# Altium schematic sheet [1]-SODIMM-COL-SOM-iMX8.SchDoc([1]-SODIMM-COL-SOM-iMX8)
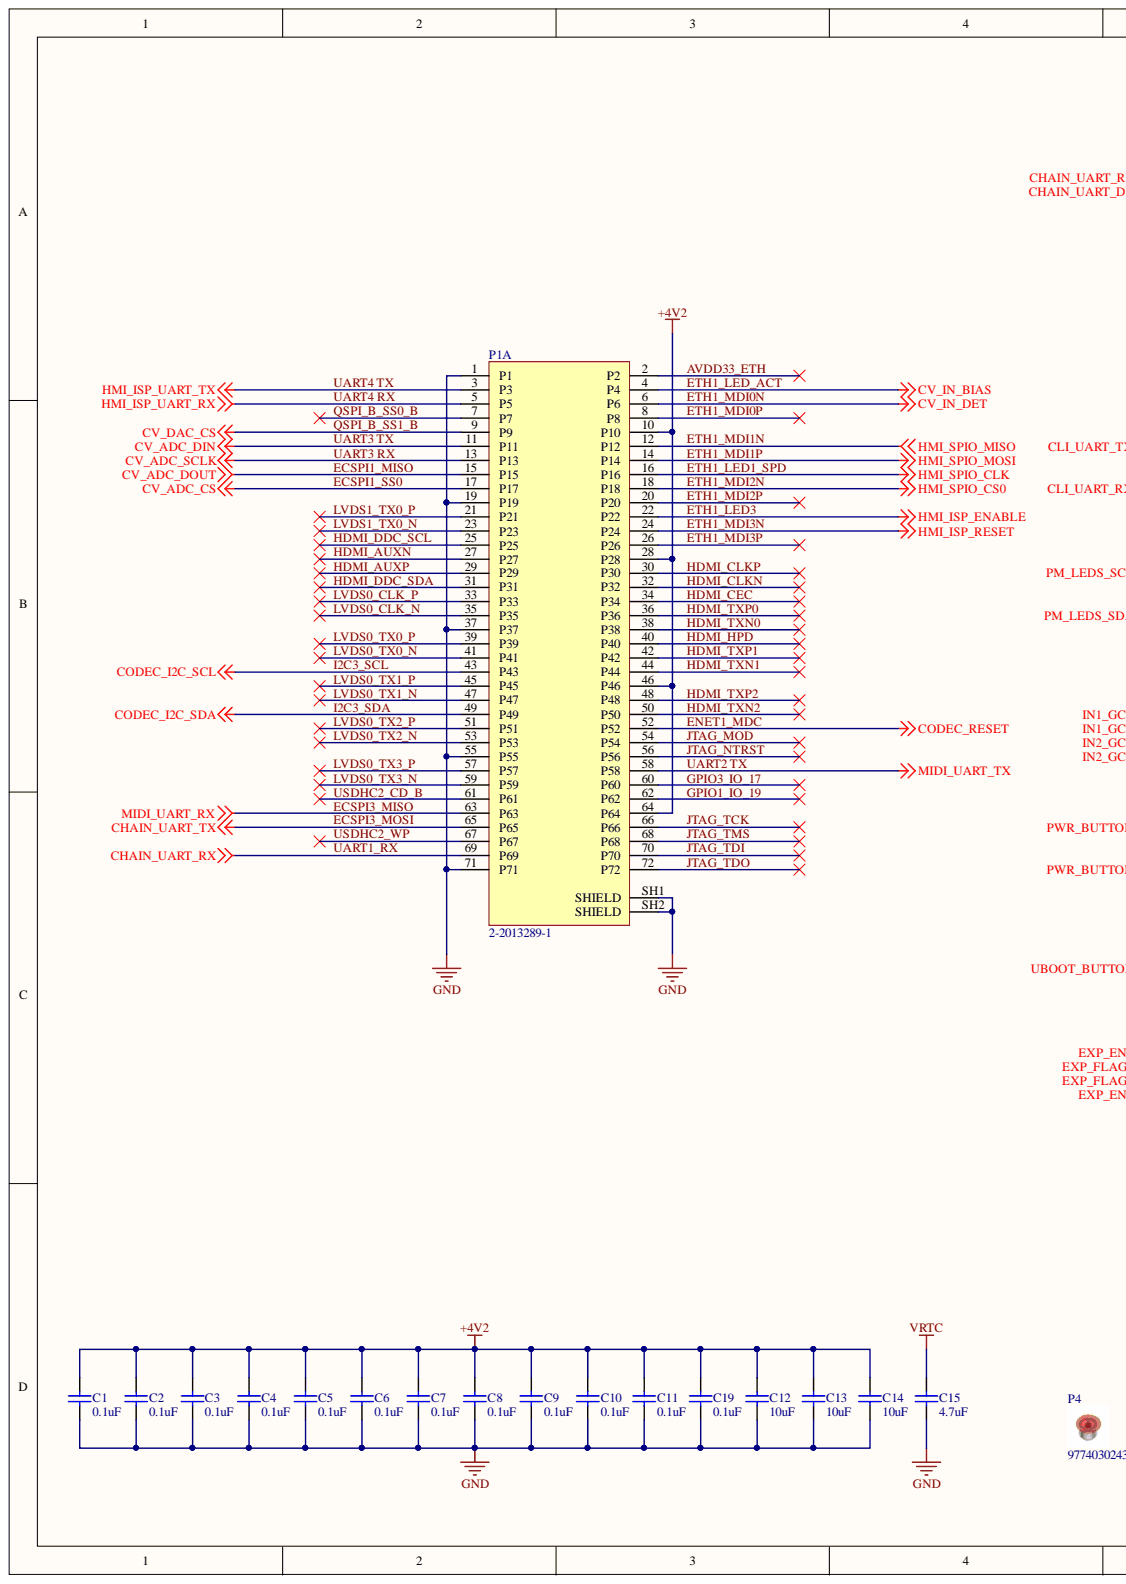
[diagram p0: [1]-SODIMM-COL-SOM-iMX8.SchDoc, left]
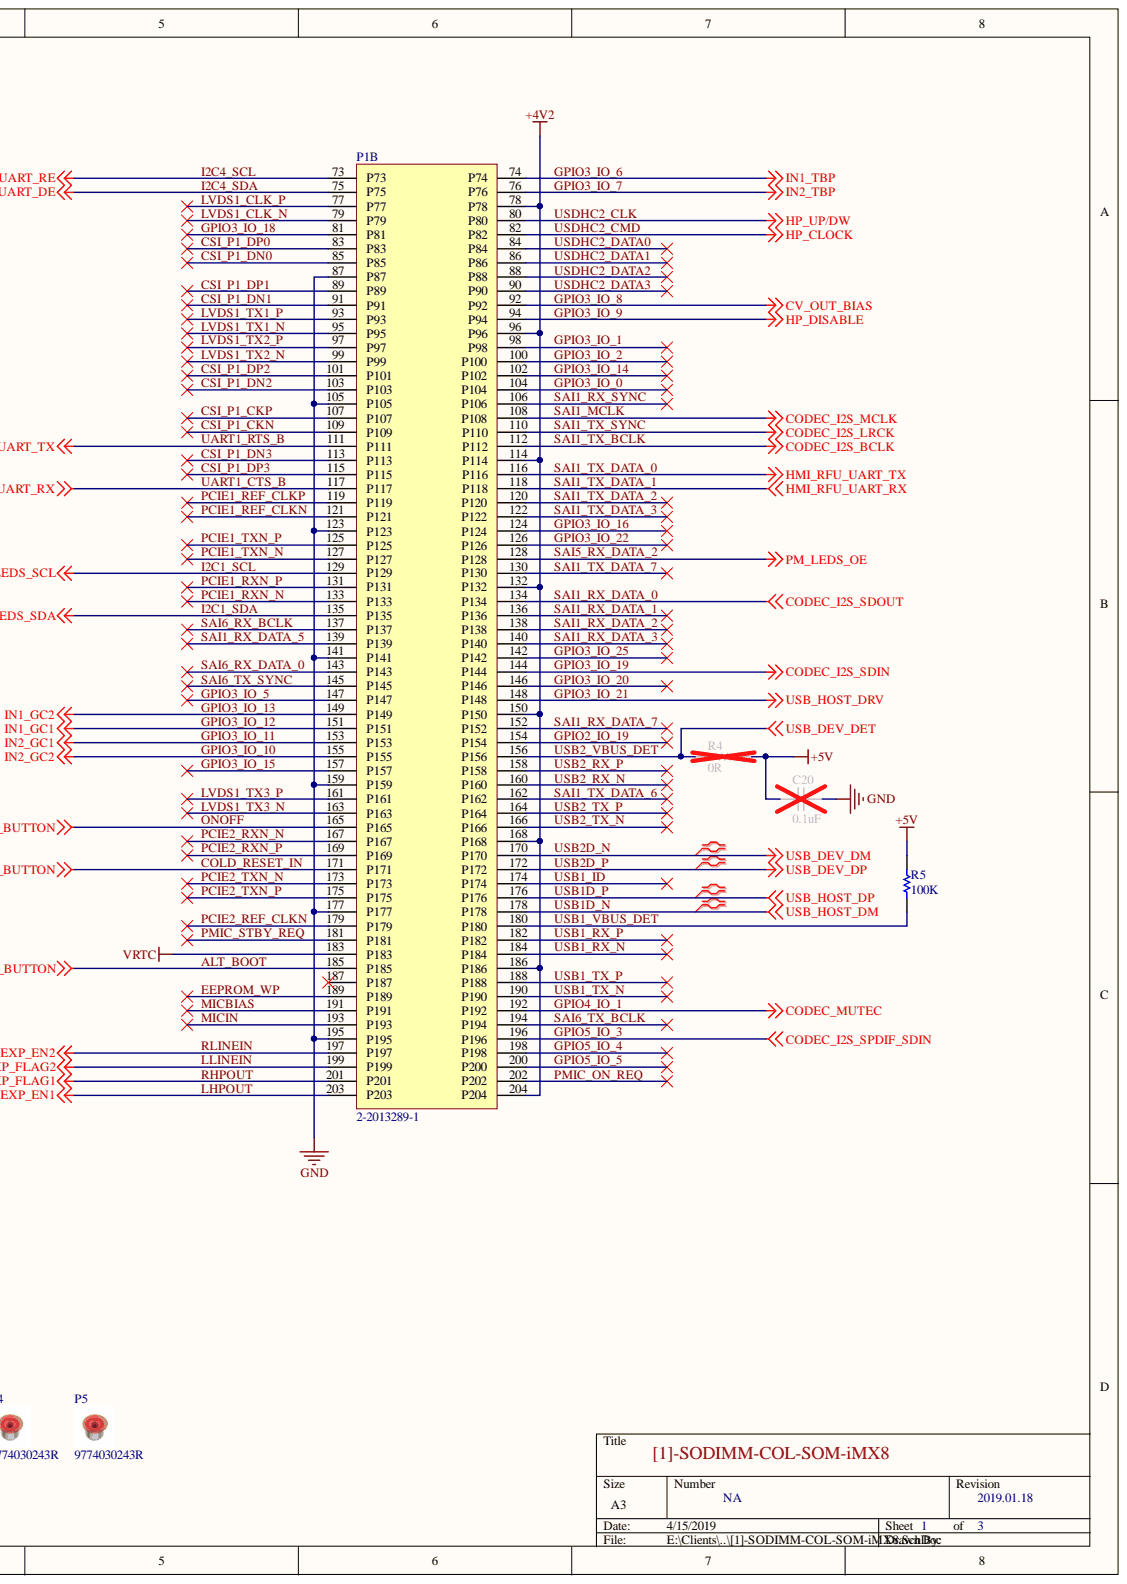
[diagram p1: [1]-SODIMM-COL-SOM-iMX8.SchDoc, right]

## Parts
C1  0.1uF  [CAPC1005N]
C2  0.1uF  [CAPC1005N]
C3  0.1uF  [CAPC1005N]
C4  0.1uF  [CAPC1005N]
C5  0.1uF  [CAPC1005N]
C6  0.1uF  [CAPC1005N]
C7  0.1uF  [CAPC1005N]
C8  0.1uF  [CAPC1005N]
C9  0.1uF  [CAPC1005N]
C10  0.1uF  [CAPC1005N]
C11  0.1uF  [CAPC1005N]
C12  10uF  [CAPC2012N]
C13  10uF  [CAPC2012N]
C14  10uF  [CAPC2012N]
C15  4.7uF  [CAPC1608N]
C19  0.1uF  [CAPC1005N]
C20  0.1uF  [CAPC1005N]
P1  2-2013289-1  [TE_2-2013289-1]  (parts A,B)
P4  9774030243R  [9774030243R]
P5  9774030243R  [9774030243R]
R4  0R  [RESC1608N]
R5  100K  [RESC1005N]

## Nets
+4V2: C1.2 C2.2 C3.2 C4.2 C5.2 C6.2 C7.2 C8.2 C9.2 C10.2 C11.2 C12.2 C13.2 C14.2 C19.2 P1.10(P10) P1.28(P28) P1.46(P46) P1.64(P64) P1.78(P78) P1.96(P96) P1.114(P114) P1.132(P132) P1.150(P150) P1.168(P168) P1.186(P186) P1.204(P204)
+5V: C20.2 R4.2 R5.2
GND: C1.1 C2.1 C3.1 C4.1 C5.1 C6.1 C7.1 C8.1 C9.1 C10.1 C11.1 C12.1 C13.1 C14.1 C15.1 C19.1 C20.1 P1.1 P1.19(P19) P1.37(P37) P1.55(P55) P1.71(P71) P1.87(P87) P1.105(P105) P1.123(P123) P1.141(P141) P1.159(P159) P1.177(P177) P1.195(P195) P1.SH1(SHIELD) P1.SH2(SHIELD)
VRTC: C15.2 P1.183(P183)
ALT_BOOT: P1.185(P185)
AVDD33_ETH: P1.2(P2)
COLD_RESET_IN: P1.165(P165) P1.171(P171)
CSI_P1_CKN: P1.109(P109)
CSI_P1_CKP: P1.107(P107)
CSI_P1_DN0: P1.85(P85)
CSI_P1_DN1: P1.91(P91)
CSI_P1_DN2: P1.103(P103)
CSI_P1_DN3: P1.113(P113)
CSI_P1_DP0: P1.83(P83)
CSI_P1_DP1: P1.89(P89)
CSI_P1_DP2: P1.101(P101)
CSI_P1_DP3: P1.115(P115)
ECSPI1_MISO: P1.15(P15)
ECSPI1_SS0: P1.17(P17)
ECSPI3_MISO: P1.63(P63)
ECSPI3_MOSI: P1.65(P65)
EEPROM_WP: P1.189(P189)
ENET1_MDC: P1.52(P52)
ETH1_LED1_SPD: P1.16(P16)
ETH1_LED3: P1.22(P22)
ETH1_LED_ACT: P1.4(P4)
ETH1_MDI0N: P1.6(P6)
ETH1_MDI0P: P1.8(P8)
ETH1_MDI1N: P1.12(P12)
ETH1_MDI1P: P1.14(P14)
ETH1_MDI2N: P1.18(P18)
ETH1_MDI2P: P1.20(P20)
ETH1_MDI3N: P1.24(P24)
ETH1_MDI3P: P1.26(P26)
GPIO1_IO_19: P1.62(P62)
GPIO2_IO_19: P1.154(P154)
GPIO3_IO_0: P1.104(P104)
GPIO3_IO_1: P1.98(P98)
GPIO3_IO_2: P1.100(P100)
GPIO3_IO_5: P1.147(P147)
GPIO3_IO_6: P1.74(P74)
GPIO3_IO_7: P1.76(P76)
GPIO3_IO_8: P1.92(P92)
GPIO3_IO_9: P1.94(P94)
GPIO3_IO_10: P1.155(P155)
GPIO3_IO_11: P1.153(P153)
GPIO3_IO_12: P1.151(P151)
GPIO3_IO_13: P1.149(P149)
GPIO3_IO_14: P1.102(P102)
GPIO3_IO_15: P1.157(P157)
GPIO3_IO_16: P1.124(P124)
GPIO3_IO_17: P1.60(P60)
GPIO3_IO_18: P1.81(P81)
GPIO3_IO_19: P1.144(P144)
GPIO3_IO_20: P1.146(P146)
GPIO3_IO_21: P1.148(P148)
GPIO3_IO_22: P1.126(P126)
GPIO3_IO_25: P1.142(P142)
GPIO4_IO_1: P1.192(P192)
GPIO5_IO_3: P1.196(P196)
GPIO5_IO_4: P1.198(P198)
GPIO5_IO_5: P1.200(P200)
HDMI_AUXN: P1.27(P27)
HDMI_AUXP: P1.29(P29)
HDMI_CEC: P1.34(P34)
HDMI_CLKN: P1.32(P32)
HDMI_CLKP: P1.30(P30)
HDMI_DDC_SCL: P1.25(P25)
HDMI_DDC_SDA: P1.31(P31)
HDMI_HPD: P1.40(P40)
HDMI_TXN0: P1.38(P38)
HDMI_TXN1: P1.44(P44)
HDMI_TXN2: P1.50(P50)
HDMI_TXP0: P1.36(P36)
HDMI_TXP1: P1.42(P42)
HDMI_TXP2: P1.48(P48)
I2C1_SCL: P1.129(P129)
I2C1_SDA: P1.135(P135)
I2C3_SCL: P1.43(P43)
I2C3_SDA: P1.49(P49)
I2C4_SCL: P1.73(P73)
I2C4_SDA: P1.75(P75)
JTAG_MOD: P1.54(P54)
JTAG_NTRST: P1.56(P56)
JTAG_TCK: P1.66(P66)
JTAG_TDI: P1.70(P70)
JTAG_TDO: P1.72(P72)
JTAG_TMS: P1.68(P68)
LHPOUT: P1.203(P203)
LLINEIN: P1.199(P199)
LVDS0_CLK_N: P1.35(P35)
LVDS0_CLK_P: P1.33(P33)
LVDS0_TX0_N: P1.41(P41)
LVDS0_TX0_P: P1.39(P39)
LVDS0_TX1_N: P1.47(P47)
LVDS0_TX1_P: P1.45(P45)
LVDS0_TX2_N: P1.53(P53)
LVDS0_TX2_P: P1.51(P51)
LVDS0_TX3_N: P1.59(P59)
LVDS0_TX3_P: P1.57(P57)
LVDS1_CLK_N: P1.79(P79)
LVDS1_CLK_P: P1.77(P77)
LVDS1_TX0_N: P1.23(P23)
LVDS1_TX0_P: P1.21(P21)
LVDS1_TX1_N: P1.95(P95)
LVDS1_TX1_P: P1.93(P93)
LVDS1_TX2_N: P1.99(P99)
LVDS1_TX2_P: P1.97(P97)
LVDS1_TX3_N: P1.163(P163)
LVDS1_TX3_P: P1.161(P161)
MICBIAS: P1.191(P191)
MICIN: P1.193(P193)
PCIE1_REF_CLKN: P1.121(P121)
PCIE1_REF_CLKP: P1.119(P119)
PCIE1_RXN_N: P1.133(P133)
PCIE1_RXN_P: P1.131(P131)
PCIE1_TXN_N: P1.127(P127)
PCIE1_TXN_P: P1.125(P125)
PCIE2_REF_CLKN: P1.179(P179)
PCIE2_RXN_N: P1.167(P167)
PCIE2_RXN_P: P1.169(P169)
PCIE2_TXN_N: P1.173(P173)
PCIE2_TXN_P: P1.175(P175)
PMIC_ON_REQ: P1.202(P202)
PMIC_STBY_REQ: P1.181(P181)
QSPI_B_SS0_B: P1.7(P7)
QSPI_B_SS1_B: P1.9(P9)
RHPOUT: P1.201(P201)
RLINEIN: P1.197(P197)
SAI1_MCLK: P1.108(P108)
SAI1_RX_DATA_0: P1.134(P134)
SAI1_RX_DATA_1: P1.136(P136)
SAI1_RX_DATA_2: P1.138(P138)
SAI1_RX_DATA_3: P1.140(P140)
SAI1_RX_DATA_5: P1.139(P139)
SAI1_RX_DATA_7: P1.152(P152)
SAI1_RX_SYNC: P1.106(P106)
SAI1_TX_BCLK: P1.112(P112)
SAI1_TX_DATA_0: P1.116(P116)
SAI1_TX_DATA_1: P1.118(P118)
SAI1_TX_DATA_2: P1.120(P120)
SAI1_TX_DATA_3: P1.122(P122)
SAI1_TX_DATA_6: P1.162(P162)
SAI1_TX_DATA_7: P1.130(P130)
SAI1_TX_SYNC: P1.110(P110)
SAI5_RX_DATA_2: P1.128(P128)
SAI6_RX_BCLK: P1.137(P137)
SAI6_RX_DATA_0: P1.143(P143)
SAI6_TX_BCLK: P1.194(P194)
SAI6_TX_SYNC: P1.145(P145)
UART1_CTS_B: P1.117(P117)
UART1_RTS_B: P1.111(P111)
UART1_RX: P1.69(P69)
UART2 TX: P1.58(P58)
UART3 RX: P1.13(P13)
UART3 TX: P1.11(P11)
UART4 RX: P1.5(P5)
UART4 TX: P1.3(P3)
USB1_ID: P1.174(P174)
USB1_RX_N: P1.184(P184)
USB1_RX_P: P1.182(P182)
USB1_TX_N: P1.190(P190)
USB1_TX_P: P1.188(P188)
USB1_VBUS_DET: P1.180(P180) R5.1
USB1D_N: P1.178(P178)
USB1D_P: P1.176(P176)
USB2_RX_N: P1.160(P160)
USB2_RX_P: P1.158(P158)
USB2_TX_N: P1.166(P166)
USB2_TX_P: P1.164(P164)
USB2_VBUS_DET: P1.156(P156) R4.1
USB2D_N: P1.170(P170)
USB2D_P: P1.172(P172)
USDHC2_CD_B: P1.61(P61)
USDHC2_CLK: P1.80(P80)
USDHC2_CMD: P1.82(P82)
USDHC2_DATA0: P1.84(P84)
USDHC2_DATA1: P1.86(P86)
USDHC2_DATA2: P1.88(P88)
USDHC2_DATA3: P1.90(P90)
USDHC2_WP: P1.67(P67)

Not connected: P1 187(P187)

## Library data of the parts
C1-C11 C19 C20 = GRM155R71H104KE14D: CAP CER 0.1UF 50V X7R 0402; Manufacturer 1: Murata; Voltage Rating DC: 50 VDC; Unit Weight: 0.000212 oz; Type: General Purpose Ceramic Capacitors; Tolerance: 10 %; Description 1: Cap Ceramic 0.1uF 50V X7R 10% SMD 0402 125C Paper T/R; Class: Class 2; Capacitance - pF: 100000 pF; Capacitance - nF: 100 nF; Brand: Murata Electronics; Width: 0.5 mm; Termination Style: SMD/SMT; Supplier Part Number 1: 490-10700-1-ND; Supplier 1: Digi-Key; Stock 1: 0; Series: GRM; RoHS: True; Product Category: Multilayer Ceramic Capacitors MLCC - SMD/SMT; Product: General Type MLCCs; Pricing 1: 1=0.1, 10=0.022, 100=0.0099, 500=0.0071, 1000=0.0056, 2500=0.0051, 5000=0.0047 (USD); Packaging: Reel; Package / Case: 0402 (1005 metric); Minimum Operating Temperature: - 55 C; Maximum Operating Temperature: + 125 C; Manufacturer Part Number 1: GRM155R71H104KE14D; Library Name: bitsnio.SchLib; Length: 1 mm; Height: 0.5 mm; Factory Pack Quantity: 10000; Dielectric: X7R; ComponentLink5URL: http://www.farnell.com/datasheets/2079156.pdf; ComponentLink5Description: http://www.farnell.com/datasheets/2079156.pdf; ComponentLink4URL: http://search.murata.co.jp/Ceramy/image/img/A01X/partnumbering_e_01.pdf; ComponentLink4Description: http://search.murata.co.jp/Ceramy/image/img/A01X/partnumbering_e_01.pdf; ComponentLink3URL: http://www.mouser.com/ds/2/281/c02e-2905.pdf; ComponentLink3Description: http://www.mouser.com/ds/2/281/c02e-2905.pdf; ComponentLink2URL: http://psearch.en.murata.com/capacitor/product/GRM155R71H104KE14%23.pdf; ComponentLink2Description: http://psearch.en.murata.com/capacitor/product/GRM155R71H104KE14%23.pdf; ComponentLink1URL: http://components.arrow.com/part/dataview/0323_07030614; ComponentLink1Description: http://components.arrow.com/part/dataview/0323_07030614; Component Kind: Standard; Case Code - mm: 1005; Case Code - in: 0402; Capacitance: 0.1 uF
C12-C14 = C2012X7R1A106K125AC: CAP CER 10UF 10V X7R 0805; Manufacturer 1: TDK; Tolerance: 10%; Dielectric Material: Ceramic; Width: 0.049inch; Weight: 0.000194oz; Voltage Rating (DC): 10V; Voltage Rating: 10V; Voltage: 10V; Thickness: 0.057inch; Termination: SMD/SMT; Supplier Part Number 1: 445-6857-1-ND; Supplier 1: Digi-Key; Stock 1: 20000; REACH SVHC: No SVHC; Radiation Hardening: No; Pricing 1: 1=0.52, 10=0.359, 100=0.2156, 500=0.16172, 1000=0.13656 (USD); Packaging: Tape and Reel; Package Quantity: 1; Number of Pins: 2; Mount: Surface Mount; Min Operating Temperature: -55°C; Max Operating Temperature: 125°C; Manufacturer Part Number 1: C2012X7R1A106K125AC; Library Name: bitsnio.SchLib; Length: 2mm; Lead Free: Lead Free; Height: 1.25mm; Dielectric: X7R; Depth: 1.25mm; Contact Plating: Matte,Tin; Composition: Ceramic; Component Kind: Standard; Case Code (Metric): 2012; Case Code (Imperial): 0805; Case/Package: 0805; Capacitance: 10uF
C15 = LMK107BJ475KAHT: CAP CER 4.7UF 10V X5R 0603; Manufacturer 1: Taiyo Yuden; Tolerance: 10%; Width: 0.031inch; Voltage Rating (DC): 10V; Voltage Rating: 10V; Thickness: 0.035inch; Termination: SMD/SMT; Supplier Part Number 1: 587-3416-1-ND; Supplier 1: Digi-Key; Stock 1: 148910; Pricing 1: 1=0.24, 10=0.166, 100=0.0839, 500=0.0574, 1000=0.0508 (USD); Packaging: Tape and Reel; Package Quantity: 4000; Mount: Surface Mount; Min Operating Temperature: -55°C; Max Operating Temperature: 85°C; Manufacturer Part Number 1: LMK107BJ475KAHT; Library Name: bitsnio.SchLib; Length: 0.063inch; Lead Free: Lead Free; Height: 0.8mm; Halogen Free: Halogen Free; Dielectric: X5R; ComponentLink3URL: https://datasheet.ciiva.com/18697/mlcc01-hq-e-18697515.pdf; ComponentLink3Description: https://datasheet.ciiva.com/18697/mlcc01-hq-e-18697515.pdf; ComponentLink2URL: https://datasheet.ciiva.com/18697/mlcc01-hq-e-18697514.pdf; ComponentLink2Description: https://datasheet.ciiva.com/18697/mlcc01-hq-e-18697514.pdf; ComponentLink1URL: https://datasheet.ciiva.com/18697/mlcc01-hq-e-18697513.pdf; ComponentLink1Description: https://datasheet.ciiva.com/18697/mlcc01-hq-e-18697513.pdf; Component Kind: Standard; Case Code (Metric): 1608; Case Code (Imperial): 0603; Case/Package: 0603; Capacitance: 4.7uF
P1 = 2-2013289-1: CONN SKT SODIMM 204POS SMD; Manufacturer 1: TE Connectivity; Voltage Rating: 1.5V; Stack Height: 5.2mm; Sealable: No (Without); Row Spacing: 0.322inch; Pitch: 0.024inch; PCB Mount Retention: With; Number of Positions: 204; Memory Type: DDR,SDRAM; Gender: Female; Contact Current Rating: 500mA; Connector System: Cable-to-Board; Circuit Application: Power; Body Material: Thermoplastic; Termination: Solder; Supplier Part Number 1: A116236CT-ND; Supplier 1: Digi-Key; Stock 1: 1312; Radiation Hardening: No; Pricing 1: 1=4.89, 10=4.688, 25=4.2808, 50=4.0768, 100=3.873 (USD); Packaging: Tape and Reel; Package Quantity: 200; Orientation: Right Angle; Number of Rows: 2; Number of Contacts: 204; Mount: Surface Mount; Min Operating Temperature: -67°C; Max Operating Temperature: 185°C; Manufacturer Part Number 1: 2-2013289-1; Library Name: bitsnio.SchLib; Length: 71.5mm; Insulation Material: Thermoplastic; Housing Material: Thermoplastic; Housing Colour: Black; Height: 5.2mm; Flammability Rating: UL94 V-0; Depth: 26.5mm; Contact Plating: Gold; Contact Material: Copper Alloy; Component Kind: Standard
P4 P5 = 9774030243R: ROUND STANDOFF M2X0.4 STEEL 3MM; Manufacturer 1: Wurth Electronics; Threaded/Unthreaded: Threaded; Plating: Tin; Outside Diameter: 0.171inch; Supplier Part Number 1: 732-7085-1-ND; Supplier 1: Digi-Key; Stock 1: 12955; REACH SVHC: Unknown; Pricing 1: 1=0.95, 10=0.918, 50=0.795, 100=0.739 (USD); Material: Steel; Manufacturer Part Number 1: 9774030243R; Library Name: bitsnio.SchLib; Length: 0.173inch; Component Kind: Standard
R4 = ERJ-3GEY0R00V: RES SMD 0 OHM JUMPER 1/10W 0603; Manufacturer: Panasonic; Voltage Rating (DC): 50V; Voltage Rating: 75V; Tolerance: 0%; Temperature Coefficient: 600ppm/°C; Resistance: 0mR; Power Rating: 100mW; Width: 0.031inch; Termination: SMD/SMT; Supplier Part Number 1: P0.0GCT-ND; Supplier 1: Digi-Key; Stock 1: 17095467; REACH SVHC: No SVHC; Radiation Hardening: No; Pricing 1: 1=0.1, 10=0.053, 25=0.038, 50=0.029, 100=0.0214, 250=0.01632, 500=0.01306, 1000=0.00962 (USD); Packaging: Tape and Reel; Package Quantity: 1; Number of Terminations: 2; Mount: Surface Mount; Min Operating Temperature: -55°C; Military Standard: Not; Max Power Dissipation: 100mW; Max Operating Temperature: 155°C; Manufacturer Part Number: ERJ-3GEY0R00V; Library Name: bitsnio.SchLib; Length: 1.6mm; Lead Free: Lead Free; Height: 0.45mm; Features: Automotive AEC-Q200; Depth: 0.8mm; Contact Plating: Tin; Composition: Thick Film; ComponentLink12URL: https://datasheet.ciiva.com/16758/70255313-16758527.pdf; ComponentLink12Description: https://datasheet.ciiva.com/16758/70255313-16758527.pdf; ComponentLink11URL: https://datasheet.ciiva.com/9198/aoa0000ce1-9198975.pdf; ComponentLink11Description: https://datasheet.ciiva.com/9198/aoa0000ce1-9198975.pdf; ComponentLink10URL: https://datasheet.ciiva.com/30199/4aoa0000ce1-30199822.pdf; ComponentLink10Description: https://datasheet.ciiva.com/30199/4aoa0000ce1-30199822.pdf; ComponentLink9URL: https://datasheet.ciiva.com/46377/1678796-46377868.pdf; ComponentLink9Description: https://datasheet.ciiva.com/46377/1678796-46377868.pdf; ComponentLink8URL: https://datasheet.ciiva.com/17215/aoa0000ce1-17215388.pdf; ComponentLink8Description: https://datasheet.ciiva.com/17215/aoa0000ce1-17215388.pdf; ComponentLink7URL: https://datasheet.ciiva.com/16834/aoa0000ce1-16834828.pdf; ComponentLink7Description: https://datasheet.ciiva.com/16834/aoa0000ce1-16834828.pdf; ComponentLink6URL: https://datasheet.ciiva.com/5959/1678796-5959707.pdf; ComponentLink6Description: https://datasheet.ciiva.com/5959/1678796-5959707.pdf; ComponentLink5URL: https://datasheet.ciiva.com/28695/1678796-28695833.pdf; ComponentLink5Description: https://datasheet.ciiva.com/28695/1678796-28695833.pdf; ComponentLink4URL: https://datasheet.ciiva.com/43460/1678796-43460647.pdf; ComponentLink4Description: https://datasheet.ciiva.com/43460/1678796-43460647.pdf; ComponentLink3URL: https://datasheet.ciiva.com/48532/aoa0000ce1-48532165.pdf; ComponentLink3Description: https://datasheet.ciiva.com/48532/aoa0000ce1-48532165.pdf; ComponentLink2URL: https://datasheet.ciiva.com/11808/0900766b80f806dd-11808207.pdf; ComponentLink2Description: https://datasheet.ciiva.com/11808/0900766b80f806dd-11808207.pdf; ComponentLink1URL: https://datasheet.ciiva.com/27055/1935748-27055113.pdf; ComponentLink1Description: https://datasheet.ciiva.com/27055/1935748-27055113.pdf; Component Kind: Standard; Case Code (Metric): 1608; Case Code (Imperial): 0603; Case/Package: 0603
R5 = RC0402FR-07100KL: RES SMD 100K OHM 1% 1/16W 0402; Manufacturer 1: Yageo; Voltage Rating: 50 V; Temperature Coefficient: 100 PPM / C; Resistance: 100 kOhms; Power Rating: 62.5 mW (1/16 W); Tolerance: 1 %; Termination Style: SMD/SMT; Supplier Part Number 1: 311-100KLRCT-ND; Supplier 1: Digi-Key; Stock 1: 10691398; Series: RC; Product: Thick Film Resistors General Purpose; Pricing 1: 1=0.1, 10=0.014, 100=0.0056, 1000=0.0025, 2500=0.0022, 5000=0.0018 (USD); Packaging: Reel; Operating Temperature Range: - 55 C to + 155 C; Manufacturer Part Number 1: RC0402FR-07100KL; Library Name: bitsnio.SchLib; Description 1: RES SMD 100K OHM 1% 1/16W 0402; ComponentLink8URL: https://datasheet.ciiva.com/22747/1018594-22747509.pdf; ComponentLink8Description: https://datasheet.ciiva.com/22747/1018594-22747509.pdf; ComponentLink7URL: https://datasheet.ciiva.com/2453/pyu-r-int-thick-7-2453363.pdf; ComponentLink7Description: https://datasheet.ciiva.com/2453/pyu-r-int-thick-7-2453363.pdf; ComponentLink6URL: https://datasheet.ciiva.com/2453/pyu-r-int-thick-7-2453362.pdf; ComponentLink6Description: https://datasheet.ciiva.com/2453/pyu-r-int-thick-7-2453362.pdf; ComponentLink5URL: https://datasheet.ciiva.com/15189/getdatasheetpartid-323696-15189334.pdf; ComponentLink5Description: https://datasheet.ciiva.com/15189/getdatasheetpartid-323696-15189334.pdf; ComponentLink4URL: https://datasheet.ciiva.com/2453/pyu-r-int-thick-7-2453361.pdf; ComponentLink4Description: https://datasheet.ciiva.com/2453/pyu-r-int-thick-7-2453361.pdf; ComponentLink3URL: https://datasheet.ciiva.com/12667/pyu-rc0402-51-rohs-l-6-r-12667930.pdf; ComponentLink3Description: https://datasheet.ciiva.com/12667/pyu-rc0402-51-rohs-l-6-r-12667930.pdf; ComponentLink2URL: https://datasheet.ciiva.com/16740/pyu-rc0402-51-rohs-l-6-r-16740567.pdf; ComponentLink2Description: https://datasheet.ciiva.com/16740/pyu-rc0402-51-rohs-l-6-r-16740567.pdf; ComponentLink1URL: https://datasheet.ciiva.com/11819/0900766b80c66297-11819452.pdf; ComponentLink1Description: https://datasheet.ciiva.com/11819/0900766b80c66297-11819452.pdf; Component Kind: Standard; Case Code - mm: 1005; Case Code - in: 0402
